# Altium schematic sheet STM32F030（051）C8T6.SchDoc
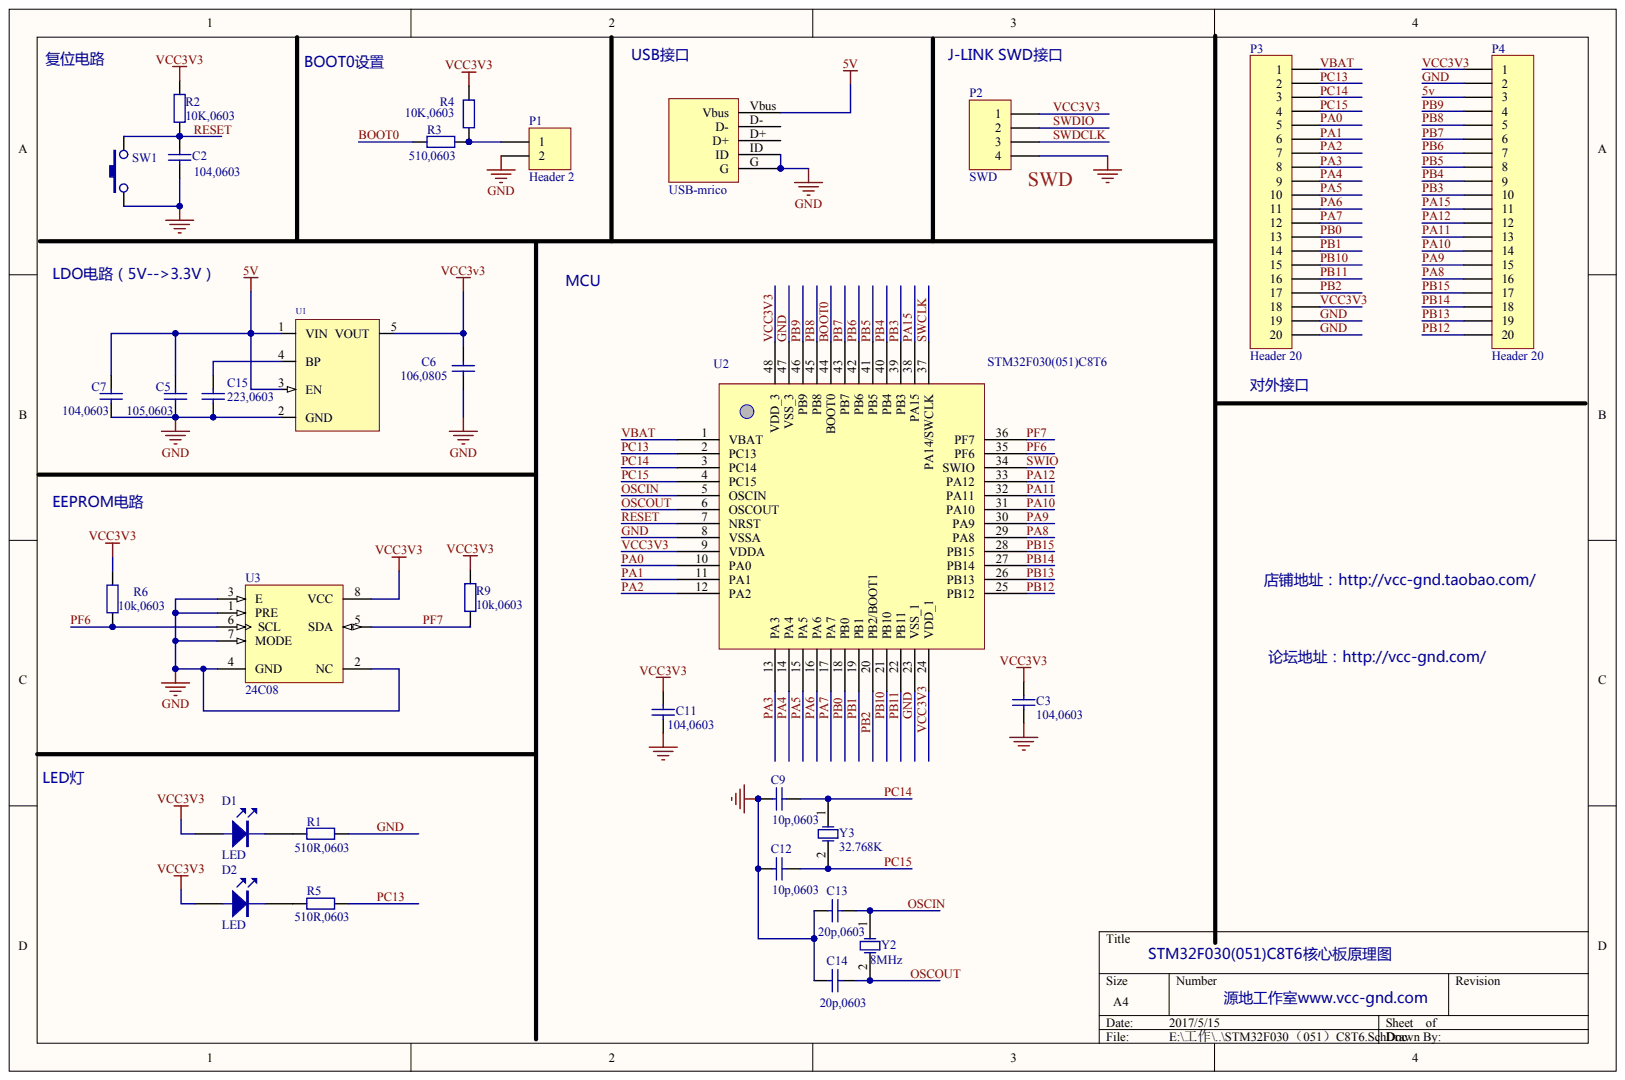
[diagram p0: STM32F030（051）C8T6.SchDoc]

## Parts
C2  104,0603
C3  104,0603
C5  105,0603
C6  106,0805
C7  104,0603
C9  10p,0603
C11  104,0603
C12  10p,0603
C13  20p,0603
C14  20p,0603
C15  223,0603
D1  LED
D2  LED
P1  Header 2
P2  SWD
P3  Header 20
P4  Header 20
R1  value 510R,0603  (hidden comment: Res2)
R2  10K,0603
R3  510,0603
R4  10K,0603
R5  value 510R,0603  (hidden comment: Res2)
R6  10k,0603
R9  10k,0603
SW1  (no value)
U1  RT9193  (value not drawn)
U2  STM32F030(051)C8T6
U3  24C08
Y2  8MHz
Y3  32.768K
  USB-mrico

## Nets
Net_D+: .D+
Net_D-: .D-
5V: C5.1 C7.1 P4.3 U1.1(VIN) U1.3(EN) .Vbus
BOOT0: R3.2 U2.44(BOOT0)
GND: C2.2 C3.1 C5.2 C6.2 C7.2 C9.2 C11.1 C12.2 C13.2 C14.2 C15.2 P1.2 P2.4 P3.19 P3.20 P4.2 R1.1 SW1.2 U1.2(GND) U2.8(VSSA) U2.23(VSS_1) U2.47(VSS_3) U3.1(PRE) U3.2(NC) U3.3(E) U3.4(GND) U3.7(MODE) .G .ID
OSCIN: C13.1 U2.5(OSCIN) Y2.1
OSCOUT: C14.1 U2.6(OSCOUT) Y2.2
PA0: P3.5 U2.10(PA0)
PA1: P3.6 U2.11(PA1)
PA2: P3.7 U2.12(PA2)
PA3: P3.8 U2.13(PA3)
PA4: P3.9 U2.14(PA4)
PA5: P3.10 U2.15(PA5)
PA6: P3.11 U2.16(PA6)
PA7: P3.12 U2.17(PA7)
PA8: P4.16 U2.29(PA8)
PA9: P4.15 U2.30(PA9)
PA10: P4.14 U2.31(PA10)
PA11: P4.13 U2.32(PA11)
PA12: P4.12 U2.33(PA12)
PA15: P4.11 U2.38(PA15)
PB0: P3.13 U2.18(PB0)
PB1: P3.14 U2.19(PB1)
PB2: P3.17 U2.20(PB2/BOOT1)
PB3: P4.10 U2.39(PB3)
PB4: P4.9 U2.40(PB4)
PB5: P4.8 U2.41(PB5)
PB6: P4.7 U2.42(PB6)
PB7: P4.6 U2.43(PB7)
PB8: P4.5 U2.45(PB8)
PB9: P4.4 U2.46(PB9)
PB10: P3.15 U2.21(PB10)
PB11: P3.16 U2.22(PB11)
PB12: P4.20 U2.25(PB12)
PB13: P4.19 U2.26(PB13)
PB14: P4.18 U2.27(PB14)
PB15: P4.17 U2.28(PB15)
PC13: P3.2 R5.1 U2.2(PC13)
PC14: C9.1 P3.3 U2.3(PC14) Y3.1
PC15: C12.1 P3.4 U2.4(PC15) Y3.2
PF6: R6.1 U2.35(PF6) U3.6(SCL)
PF7: R9.1 U2.36(PF7) U3.5(SDA)
RESET: C2.1 R2.2 SW1.1 U2.7(NRST)
SWCLK: U2.37(PA14/SWCLK)
SWDCLK: P2.3
SWDIO: P2.2
SWIO: U2.34(SWIO)
VBAT: P3.1 U2.1(VBAT)
VCC3V3: C3.2 C6.1 C11.2 D1.A D2.A P2.1 P3.18 P4.1 R2.1 R4.1 R6.2 R9.2 U1.5(VOUT) U2.9(VDDA) U2.24(VDD_1) U2.48(VDD_3) U3.8(VCC)
NetC15_1: C15.1 U1.4(BP)
NetD1_K: D1.K R1.2
NetD2_K: D2.K R5.2
NetP1_1: P1.1 R3.1 R4.2

## Library data of the parts
C2 C3 C11 = (no symbol name): Value: 104; Published: 8-Jun-2000; LatestRevisionDate: 17-Jul-2002; LatestRevisionNote: Re-released for DXP Platform.; Publisher: Altium Limited
C5-C7 C9 C12 C15 = (no symbol name): Value: 10; Published: 8-Jun-2000; LatestRevisionDate: 17-Jul-2002; LatestRevisionNote: Re-released for DXP Platform.; Publisher: Altium Limited
C13 C14 = (no symbol name): Value: 22; Published: 8-Jun-2000; LatestRevisionDate: 17-Jul-2002; LatestRevisionNote: Re-released for DXP Platform.; Publisher: Altium Limited
U1 = (no symbol name): ICA_MFR_NAME: RICHTEK; ICA_PARTNUM: $$2191094$$RT9193-15CU5; Part Number: TMP-13
D1 D2 P1-P4 R1-R6 R9 SW1 U2 U3 Y2 Y3  = (no symbol name)
  P1-P4: LatestRevisionDate: 17-Jul-2002; LatestRevisionNote: Re-released for DXP Platform.
  R1 R5: Published: 8-Jun-2000; LatestRevisionDate: 17-Jul-2002; LatestRevisionNote: Re-released for DXP Platform.; PackageReference: AXIAL-0.4
  R2-R4 Y2 Y3: Published: 8-Jun-2000; LatestRevisionDate: 17-Jul-2002; LatestRevisionNote: Re-released for DXP Platform.
  R6 R9: PackageReference: AXIAL-0.4
  U3: Published: 24-Aug-2001; LatestRevisionDate: 06-Jun-2005; LatestRevisionNote: Stylized 3D Model Added.; DatasheetVersion: Jul-1996; PackageVersion: Jun-1998; PackageReference: PSDIP8A; PackageDescription: DIP; 8 Leads; Row Spacing 7.62 mm; Pitch 2.54 mm; ComponentLink1Description: Manufacturer Link; ComponentLink1URL: http://www.st.com/
  P1-P4 R1-R5 U3 Y2 Y3: Publisher: Altium Limited
